# Altium schematic sheet PS_Board_p1.SchDoc
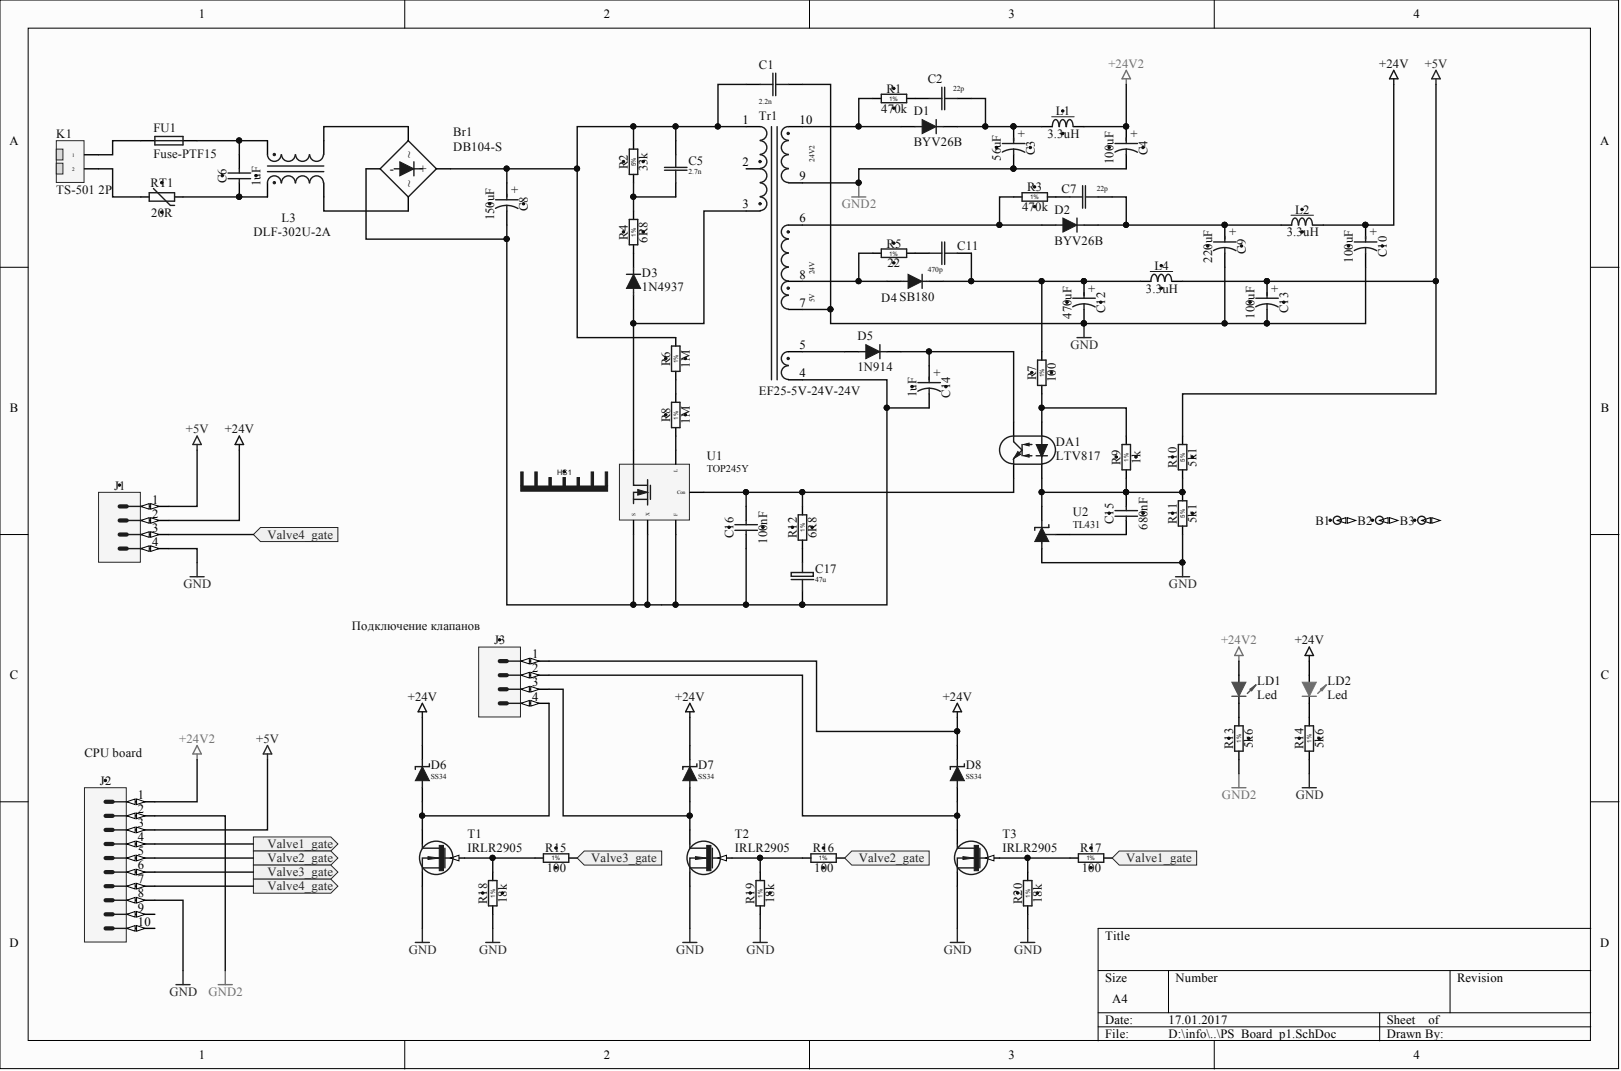
[diagram p0: PS_Board_p1.SchDoc]

## Parts
B1  Plated Hole  [MTG350_650]  (value not drawn)  (no BOM)
B2  Plated Hole  [MTG350_650]  (value not drawn)  (no BOM)
B3  Plated Hole  [MTG350_650]  (value not drawn)  (no BOM)
Br1  DB104-S  [DB-S]
C1  2.2n  [C Y2 7.5b]
C2  22p  [Cap-Disk-D5.5-R5.08]
C3  56uF  [CAPPR500-1050X1150]
C4  100uF  [CAPPR350-850X800]
C5  2.7n  [C Y2 7.5b]
C6  1uF  [CAPR2750-1300X3150X2400]
C7  22p  [Cap-Disk-D5.5-R5.08]
C8  150uF  [CAPPR1000-2300X4200]
C9  220uF  [CAPPR350-850X1300]
C10  100uF  [CAPPR350-850X800]
C11  470p  [Cap-Disk-D5.5-R5.08]
C12  470uF  [CAPPR500-1050X2200]
C13  100uF  [CAPPR350-850X800]
C14  1uF  [CAPPRB254-450X710]
C15  680nF  [CAPC2012X135N]
C16  100nF  [CAPC2012X135N]
C17  47u  [El-d5-h11-F2.54]
D1  BYV26B  [SOD-64-300]
D2  BYV26B  [SOD-64-300]
D3  1N4937  [DO-41-300]
D4  SB180  [DO-41-300]
D5  1N914  [DO-35-300]
D6  SS34  [DO-214AB (SMC)]
D7  SS34  [DO-214AB (SMC)]
D8  SS34  [DO-214AB (SMC)]
DA1  LTV817  [DIL4-300a]
FU1  Fuse-PTF15  [FUSE-MCH]
HS1  Heat Sink No Pad  [HSINK_FISCHER_FK 242 SA 220 O_V]  (value not drawn)
J1  KK®  [MOLEX_22-27-2041]  (value not drawn)
J2  C-Grid®  [MOLEX_70246-1004]  (value not drawn)
J3  KK®  [MOLEX_22-27-2041]  (value not drawn)
K1  TS-501 2P  [TS-501 2P-2.5]
L1  3.3uH  [IND_WURTH_WE-TI]
L2  3.3uH  [IND_WURTH_WE-TI]
L3  DLF-302U-2A  [DLF-302U-2A]
L4  3.3uH  [IND_WURTH_WE-TI]
LD1  Led  [Led 0805-Red]
LD2  Led  [Led 0805-Green]
R1  470k  [RES1016-630X250]
R2  33k  [RES2286-1670X520]
R3  470k  [RES1016-630X250]
R4  6R8  [RES1016-630X250]
R5  22  [RES1016-630X250]
R6  1M  [RES1016-630X250]
R7  100  [RES1016-630X250]
R8  1M  [RES1016-630X250]
R9  1k  [RES1016-630X250]
R10  5k1  [RES_ARCOL_RCC025]
R11  5k1  [RES_ARCOL_RCC025]
R12  6R8  [RES1016-630X250]
R13  5k6  [RESC2012X60N]
R14  5k6  [RESC2012X60N]
R15  100  [RESC2012X60N]
R16  100  [RESC2012X60N]
R17  100  [RESC2012X60N]
R18  18k  [RESC2012X60N]
R19  18k  [RESC2012X60N]
R20  18k  [RESC2012X60N]
RT1  20R  [THERM_EPCOS_B57153S0]
T1  IRLR2905  [TO252AA]
T2  IRLR2905  [TO252AA]
T3  IRLR2905  [TO252AA]
Tr1  EF25-5V-24V-24V  [SP-EF25]
U1  TOP245Y  [TO220-7C]
U2  TL431  [TO92C]

## Ports
VALVE1_GATE, VALVE2_GATE, VALVE3_GATE, VALVE4_GATE

## Nets
+5V: C13.1 J1.1 J2.3 L4.2 R10.2
+24V: C10.1 D6.2 D7.2 D8.2 J1.2 J3.1 L2.2 LD2.1
+24V2: C4.1 J2.1 L1.2 LD1.1
GND: C1.2 C9.2 C10.2 C12.2 C13.2 J1.4 J2.8 R11.1 R14.1 R18.1 R19.1 R20.1 T1.3 T2.3 T3.3 Tr1.7 U2.2
GND2: C3.2 C4.2 J2.2 R13.1 Tr1.9
NetBr1_1: Br1.1(+) C1.1 C5.2 C8.1 R2.2 R6.2 Tr1.1
NetBr1_2: Br1.2(-) C8.2 C14.2 C16.1 C17.2 Tr1.4 U1.3 U1.4(S) U1.5(F)
NetBr1_3: Br1.3(~) L3.3
NetBr1_4: Br1.4(~) L3.4
NetC2_1: C2.1 R1.2
NetC2_2: C2.2 C3.1 D1.2 L1.1
NetC5_1: C5.1 R2.1 R4.2
NetC6_1: C6.1 L3.2 RT1.2
NetC6_2: C6.2 FU1.1 L3.1
NetC7_1: C7.1 R3.2
NetC7_2: C7.2 C9.1 D2.2 L2.1
NetC11_1: C11.1 R5.2
NetC11_2: C11.2 C12.1 D4.2 L4.1 R7.2
NetC14_1: C14.1 D5.2 DA1.4
NetC15_1: C15.1 U2.3
NetC15_2: C15.2 DA1.2 R9.1 R10.1 R11.2 U2.1
NetC16_2: C16.2 DA1.3 R12.2 U1.1(Con)
NetC17_1: C17.1 R12.1
NetD1_1: D1.1 R1.1 Tr1.10
NetD2_1: D2.1 R3.1 Tr1.6
NetD3_1: D3.1 Tr1.3 U1.7
NetD3_2: D3.2 R4.1
NetD4_1: D4.1 R5.1 Tr1.8
NetD5_1: D5.1 Tr1.5
NetD6_1: D6.1 J3.4 T1.2
NetD7_1: D7.1 J3.3 T2.2
NetD8_1: D8.1 J3.2 T3.2
NetDA1_1: DA1.1 R7.1 R9.2
NetFU1_2: FU1.2 K1.1
NetJ1_3: J1.3 J2.7 ; port VALVE4_GATE
NetJ2_4: J2.4 R17.2 ; port VALVE1_GATE
NetJ2_5: J2.5 R16.2 ; port VALVE2_GATE
NetJ2_6: J2.6 R15.2 ; port VALVE3_GATE
NetK1_2: K1.2 RT1.1
NetLD1_2: LD1.2 R13.2
NetLD2_2: LD2.2 R14.2
NetR6_1: R6.1 R8.2
NetR8_1: R8.1 U1.2(L)
NetR15_1: R15.1 R18.2 T1.1
NetR16_1: R16.1 R19.2 T2.1
NetR17_1: R17.1 R20.2 T3.1

Not connected: B1 1; B2 1; B3 1; J2 9 10; Tr1 2

## Library data of the parts
B1-B3 = PLATED_HOLE3.5_PAD6.5: Single Terminal Socket; Part Description: Plated Through Hole: Hole Dia.=3.5mm Pad Dia.=6.5mm; Database Table Name: Pads; Mounted: No; ComponentHeight: 0mm; Component Type: Standard (No BOM); CreateDate: 20.11.2008; Family: Plated Hole; LatestRevisionDate: 23.11.2011; Library Name: Eletro-mechanical.DbLib; PackageDescription: Plated Through Hole: Hole Dia.=3.5mm Pad Dia.=6.5mm; Part Number: PLATED_HOLE3.5_PAD6.5; Pin Count: 1; SMD: No; Socket: No; Status: None; Table: Pads; Author: CERN DEM JLC
Br1 = DB104-S: SMD 1A 400V; Component Kind: Standard; Library Name: Diodes-Bridge.IntLib; Price: 0.23; Signal Integrity: D
C1 C5 = Y2 1n 250V AC: Y2 250V AC Y5P; Tip: Ceramic  Disc Y2 1nF 250VAC Y5P; Component Kind: Standard; Library Name: Cap.IntLib; Price: 0.1; Signal Integrity: C; Simulation: C
  C1: Value: 2.2n
  C5: Value: 2.7n
C2 C7 = Cer Disc HV 220p 1kV: 22p 1kV Y5P; Tip: Suntan TS16 220pF 1kV Y5P; Component Kind: Standard; Library Name: Cap.IntLib; Price: 0.072; Signal Integrity: C; Simulation: C; Value: 22p
C3 = CAER_56UF_25V_20%_500-1050X1150_LESR0R025: Polarised capacitor; Manufacturer: SANYO OS-CON; Manufacturer Part Number: 25SP56M; Part Description: Low ESR 0R025 Aluminum Solid Capacitor With Organic Semiconductive Electrolyte; Database Table Name: Capacitors THD; Tolerance: ±20%; Voltage: 25V; Case: LS5 D10.5 x H11.5; ComponentHeight: 11.5mm; Component Kind: Standard; Component Type: Standard; CreateDate: 29.01.2008; HelpURL: \\cern.ch\dfs\Applications\Altium\Datasheets\CAER-LESR_SANYO_SP.pdf; LatestRevisionDate: 16.03.2009; Library Name: Capacitors.DbLib; Mounted: Yes; Part Number: CAER_56UF_25V_20%_500-1050X1150_LESR0R025; Pin Count: 2; SMD: No; Socket: No; Status: Preferred; Table: Capacitors THD; Value: 56uF; Author: CERN DEM JLC
C4 C10 C13 = CAER_100UF_25V_20%_RUBYCON_25ML100M8X7: Polarised capacitor; Part Description: Aluminum Electrolytic Capacitor, (ML Series); Database Table Name: Capacitors THD; Tolerance: ±20%; Voltage: 25V; Case: LS3.5 D8.5 x H8; ComponentHeight: 8mm; Component Kind: Standard; Component Type: Standard; CreateDate: 17.11.2008; HelpURL: \\cern.ch\dfs\Applications\Altium\Datasheets\CAER_RUBYCON_25ML100M8X7.pdf; LatestRevisionDate: 08.05.2013; Library Name: Capacitors.DbLib; Manufacturer: RUBYCON; Manufacturer Part Number: 25ML100M8X7; Mounted: Yes; Part Number: CAER_100UF_25V_20%_RUBYCON_25ML100M8X7; Pin Count: 2; SMD: No; Socket: No; Status: None; Table: Capacitors THD; Value: 100uF; Author: CERN DEM JMW
C6 = CPPR_1UF_275VAC_20%_2750-1300X3150X2400: Non-polarised capacitor; Manufacturer: WIMA; Manufacturer Part Number: MKX21W41006D00MSSD; Part Description: Metallized Polypropylene RFI Capacitor Class X2 (MKP-X2); Database Table Name: Capacitors THD; Tolerance: ±20%; Voltage: 275VAC; Case: LS27.5 W13 x L31.5 x H24; ComponentHeight: 24mm; Component Kind: Standard; Component Type: Standard; CreateDate: 23.06.2008; HelpURL: \\cern.ch\dfs\Applications\Altium\Datasheets\CPPR_WIMA_MKP-X2.pdf; LatestRevisionDate: 30.01.2012; Library Name: Capacitors.DbLib; Mounted: Yes; Part Number: CPPR_1UF_275VAC_20%_2750-1300X3150X2400; Pin Count: 2; SMD: No; Socket: No; Status: None; Table: Capacitors THD; Value: 1uF; Author: CERN DEM JLC
C8 = CAER_150UF_400V_20%_EPCOS_B43501A9157M000: Polarised capacitor; Manufacturer: EPCOS; Part Description: Aluminum Electrolytic Capacitor  (B43501 Series); Database Table Name: Capacitors THD; Tolerance: ±20%; Voltage: 400V; Case: LS10 D22 x H40; ComponentHeight: 42mm; Component Kind: Standard; Component Type: Standard; CreateDate: 15.09.2011; HelpURL: \\cern.ch\dfs\Applications\Altium\Datasheets\CAER_EPCOS_B43501.pdf; LatestRevisionDate: 15.09.2011; Library Name: Capacitors.DbLib; Manufacturer Part Number: B43501A9157M000; Mounted: Yes; Part Number: CAER_150UF_400V_20%_EPCOS_B43501A9157M000; Pin Count: 2; SMD: No; Socket: No; Status: None; Table: Capacitors THD; Value: 150uF; Author: CERN DEM JLC
C9 = CAER_220UF_25V_20%_RUBYCON_25YXF220M8X11.5: Polarised capacitor; Part Description: Radial Miniature, Aluminum Electrolytic Capacitor, (YXF Series); Database Table Name: Capacitors THD; Tolerance: ±20%; Voltage: 25V; Case: LS3.5 D8.5 x H13; ComponentHeight: 13mm; Component Kind: Standard; Component Type: Standard; CreateDate: 20.08.2009; HelpURL: \\cern.ch\dfs\Applications\Altium\Datasheets\CAER_RUBYCON_YXF.pdf; LatestRevisionDate: 17.09.2009; Library Name: Capacitors.DbLib; Manufacturer: RUBYCON; Manufacturer Part Number: 25YXF220M8X11.5; Mounted: Yes; Part Number: CAER_220UF_25V_20%_RUBYCON_25YXF220M8X11.5; Pin Count: 2; SMD: No; Socket: No; Status: None; Table: Capacitors THD; Value: 220uF; Author: CERN DEM JLC
C11 = Cer Disc HV 220p 1kV: 470p 1kV Y5P; Tip: Suntan TS16 220pF 1kV Y5P; Component Kind: Standard; Library Name: Cap.IntLib; Price: 0.072; Signal Integrity: C; Simulation: C; Value: 470p
C12 = CAER_470UF_25V_20%_500-1050X2200_LESR0R062: Polarised capacitor; Manufacturer: VISHAY BCCOMPONENTS; Manufacturer Part Number: MAL213666471E3 Series 136RVI; Part Description: Low ESR 0R062 Aluminum Electrolytic Capacitor; Database Table Name: Capacitors THD; Tolerance: ±20%; Voltage: 25V; Case: LS5 D10.5 x H22; ComponentHeight: 22mm; Component Kind: Standard; Component Type: Standard; CreateDate: 03.07.2008; HelpURL: \\cern.ch\dfs\Applications\Altium\Datasheets\CAER-LESR_VISHAY_136RVI.pdf; LatestRevisionDate: 16.03.2009; Library Name: Capacitors.DbLib; Mounted: Yes; Part Number: CAER_470UF_25V_20%_500-1050X2200_LESR0R062; Pin Count: 2; SMD: No; Socket: No; Status: Preferred; Table: Capacitors THD; Value: 470uF; Author: CERN DEM JLC
C14 = CTEB_1UF_35V_10%_254-450X710: Polarised capacitor; Manufacturer: KEMET; Manufacturer Part Number: T350A105K035AT; Part Description: Solid Tantalum Resin Dipped Capacitor; Database Table Name: Capacitors THD; Tolerance: ±10%; Voltage: 35V; Case: LS2.54 D4.5 x H7.1; ComponentHeight: 7.1mm; Component Kind: Standard; Component Type: Standard; CreateDate: 16.12.2009; HelpURL: \\cern.ch\dfs\Applications\Altium\Datasheets\CTEB_KEMET_T350.pdf; LatestRevisionDate: 16.12.2009; Library Name: Capacitors.DbLib; Mounted: Yes; Part Number: CTEB_1UF_35V_10%_254-450X710; Pin Count: 2; SMD: No; Socket: No; Status: None; Table: Capacitors THD; Value: 1uF; Author: CERN DEM JLC
C15 = CC0805_680NF_16V_10%_X7R: Non-polarised capacitor; Manufacturer: GENERIC; Manufacturer1 Part Number: C0805C684K5RAC; Part Description: SMD Multilayer Chip Ceramic Capacitor; Database Table Name: Capacitors SMD; TC: X7R; Tolerance: ±10%; Voltage: 16V; Case: 0805; Component Kind: Standard; Component Type: Standard; CreateDate: 03.12.2007; HelpURL: \\cern.ch\dfs\Applications\Altium\Datasheets\CC0805_X7R_KEMET.pdf; LatestRevisionDate: 03.12.2007; Library Name: Capacitors.DbLib; Manufacturer1 ComponentHeight: 1.4mm; Manufacturer1 Example: KEMET; Manufacturer Part Number: CC0805_680NF_16V_10%_X7R; Mounted: Yes; Part Number: CC0805_680NF_16V_10%_X7R; Pin Count: 2; SMD: Yes; Socket: No; Status: Preferred; Table: Capacitors SMD; Value: 680nF; Author: CERN DEM JLC
C16 = CC0805_100NF_50V_10%_X7R: Non-polarised capacitor; Manufacturer: GENERIC; Manufacturer1 Part Number: B37941K5104K62; Part Description: SMD Multilayer Chip Ceramic Capacitor; Database Table Name: Capacitors SMD; TC: X7R; Tolerance: ±10%; Voltage: 50V; Case: 0805; Component Kind: Standard; Component Type: Standard; CreateDate: 03.12.2007; HelpURL: \\cern.ch\dfs\Applications\Altium\Datasheets\CC0805_X7R_EPCOS.pdf; LatestRevisionDate: 03.12.2007; Library Name: Capacitors.DbLib; Manufacturer1 ComponentHeight: 1.35mm; Manufacturer1 Example: EPCOS; Manufacturer Part Number: CC0805_100NF_50V_10%_X7R; Mounted: Yes; Part Number: CC0805_100NF_50V_10%_X7R; Pin Count: 2; SMD: Yes; Socket: No; Status: Preferred; Table: Capacitors SMD; Value: 100nF; Author: CERN DEM JLC
C17 = 47u-25V-105: 25V-105; Component Kind: Standard; Library Name: Cap-El.IntLib; Price: 0.06; Signal Integrity: C; Simulation: C; Value: 47u
D1 D2 = BYV27-100: 100V 2A 25nS; Component Kind: Standard; Library Name: Diodes-Power.IntLib; Price: 0.58; Signal Integrity: D; Simulation: BYV27-100
D3 = 1N4007: 1000V 1A; Component Kind: Standard; Library Name: Diodes-Power.IntLib; Price: 0.05; Signal Integrity: D; Simulation: D1N4007
D4 = 1N4007: Component Kind: Standard; Library Name: Diodes-Power.IntLib; Price: 0.05; Signal Integrity: D; Simulation: D1N4007
D5 = 1N4148: DO-35 75V 0.2A 1uS 4A 4nS; Component Kind: Standard; Library Name: Diodes.IntLib; Price: 0.02; Signal Integrity: D; Simulation: 1N4148
D6-D8 = SS34: SMD SMC  40V 3A; Component Kind: Standard; Library Name: Diodes-Power.IntLib; Price: 0.14; Signal Integrity: D
FU1 = Fuse-PTF15: 5mm 6.3A; Component Kind: Standard; Library Name: Devise.IntLib; Price: 1
HS1 = HSINK_FISCHER_FK 242 SA 220 O_v: Heat Sink Without Pad; Manufacturer: FISCHER ELEKTRONIK; Part Description: TO-220 Heat Sink  Rth: 26°C/W - Vertical Mount; Database Table Name: Heat-Sinks; ComponentHeight: 15.24mm; Component Kind: Standard; Component Type: Standard; CreateDate: 02.11.2009; HelpURL: \\cern.ch\dfs\Applications\Altium\Datasheets\HSINK_FISCHER_FK 242 SA 220 O.pdf; LatestRevisionDate: 10.10.2011; Library Name: Eletro-mechanical.DbLib; Manufacturer Part Number: FK 242 SA 220 O; Mounted: Yes; PackageDescription: TO-220 Heat Sink  Rth: 26°C/W - Vertical Mount; Part Number: HSINK_FISCHER_FK 242 SA 220 O_v; Pin Count: 0; SMD: No; Socket: No; Status: None; Table: Heat-Sinks; Author: CERN DEM JLC
J1 J3 = MOLEX_22-27-2041: Connector 4 Male; Part Description: 2.54mm (.100") Pitch KK® Wire-to-Board Header, Vertical, with Friction Lock, 4 Circuits, Tin (Sn) Plating; Database Table Name: MOLEX; ComponentHeight: 11.7mm; Component Kind: Standard; Component Type: Standard; CreateDate: 04.12.2008; Family: KK®; HelpURL: \\cern.ch\dfs\Applications\Altium\Datasheets\MOLEX_22-27-2041.pdf; LatestRevisionDate: 04.12.2008; Library Name: Connectors.DbLib; Manufacturer: MOLEX; Manufacturer Part Number: 22-27-2041; Mounted: Yes; Part Number: MOLEX_22-27-2041; Pin Count: 4; SMD: No; Socket: No; Status: None; Table: MOLEX; Author: CERN DEM JLC
J2 = MOLEX_70246-1004: Connector 10 Male; Part Description: 2.54mm Pitch C-Grid® Header, Low Profile, Dual Row, Vertical, Shrouded Header; Database Table Name: MOLEX; ComponentHeight: 9.27mm; Component Kind: Standard; Component Type: Standard; CreateDate: 14.08.2013; Family: C-Grid®; HelpURL: \\cern.ch\dfs\Applications\Altium\Datasheets\MOLEX_70246-1004.pdf; LatestRevisionDate: 14.08.2013; Library Name: Connectors.DbLib; Manufacturer: MOLEX; Manufacturer Part Number: 70246-1004; Mounted: Yes; Part Number: MOLEX_70246-1004; Pin Count: 10; SMD: No; Socket: No; Status: None; Table: MOLEX; Author: CERN DEM JLC
K1 = TS-501 2P: 10A/300V AC 5mm; Component Kind: Standard; Library Name: Connektor.IntLib; Price: 0.27
L1 L2 L4 = IND_3.3UH_20%_WURTH_WE-TI: Inductor With Magnetic core; Manufacturer: WURTH ELEKTRONIK; Manufacturer Part Number: 744772033; Part Description: Radial Leaded Filter Choke Serie WE-TI; Database Table Name: Inductors THD; Power: 5A; Resistance: 0.013R; Tolerance: ±20%; Case: LS5.08 H10 x D8.3; ComponentHeight: 10mm; Component Kind: Standard; Component Type: Standard; CreateDate: 11.11.2008; HelpURL: \\cern.ch\dfs\Applications\Altium\Datasheets\IND_WURTH_WE-TI_744772033.pdf; LatestRevisionDate: 09.04.2014; Library Name: Inductors & Transformers.DbLib; Mounted: Yes; Part Number: IND_3.3UH_20%_WURTH_WE-TI; Pin Count: 2; SMD: No; Socket: No; Table: Inductors THD; Value: 3.3uH; Author: CERN DEM JLC
LD1 = Led 0805 KP-2012EC: Red  627nm 12mcd; Component Kind: Standard; Library Name: Ind LED-LCD.IntLib; Price: 0.09
LD2 = Led 0805 KP-2012SGC: Green 565nm 15mcd; Component Kind: Standard; Library Name: Ind LED-LCD.IntLib; Price: 0.09
R1 R3 = RMF_470K_1%_0.6W_50PPM_1016-630X250: Resistor - 1%; Manufacturer: GENERIC; Manufacturer1 Part Number: MBB02070C4703FCT00; Part Description: Leaded Metal Film Resistor; Database Table Name: Resistors THD; Power: 0.6W; TC: ±50ppm/°C; Case: LS10.16 L6.3 x D2.5; ComponentHeight: 2.5mm; Component Kind: Standard; Component Type: Standard; CreateDate: 04.12.2007; HelpURL: \\cern.ch\dfs\Applications\Altium\Datasheets\RMF_VISHAY_MBB0207.pdf; LatestRevisionDate: 07.04.2008; Library Name: Resistors.DbLib; Manufacturer1 ComponentHeight: 2.5mm; Manufacturer1 Example: VISHAY BEYSCHLAG; Manufacturer Part Number: RMF_470K_1%_0.6W_50PPM_1016-630X250; Mounted: Yes; Part Number: RMF_470K_1%_0.6W_50PPM_1016-630X250; Pin Count: 2; SMD: No; Socket: No; Status: Preferred; Table: Resistors THD; Tolerance: ±1%; Value: 470k; Author: CERN DEM JLC
R2 = RMF_33K_5%_3W_250PPM_2286-1670X520: Resistor - 5%; Manufacturer: GENERIC; Manufacturer1 Part Number: PR03000203302JAC00; Part Description: Leaded Power Metal Film Resistor; Database Table Name: Resistors THD; Power: 3W; TC: ±250ppm/°C; Case: LS22.86 L16.7 x D5.2; ComponentHeight: 5.2mm; Component Kind: Standard; Component Type: Standard; CreateDate: 23.06.2008; HelpURL: \\cern.ch\dfs\Applications\Altium\Datasheets\RMF_VISHAY_PR03.pdf; LatestRevisionDate: 01.10.2012; Library Name: Resistors.DbLib; Manufacturer1 ComponentHeight: 5.2mm; Manufacturer1 Example: VISHAY BCCOMPONENT; Manufacturer Part Number: RMF_33K_5%_3W_250PPM_2286-1670X520; Mounted: Yes; Part Number: RMF_33K_5%_3W_250PPM_2286-1670X520; Pin Count: 2; SMD: No; Socket: No; Status: Preferred; Table: Resistors THD; Tolerance: ±5%; Value: 33k; Author: CERN DEM JLC
R4 R12 = RMF_6R8_1%_0.6W_50PPM_1016-630X250: Resistor - 1%; Manufacturer: GENERIC; Manufacturer1 Part Number: MBB02070C6808FCT00; Part Description: Leaded Metal Film Resistor; Database Table Name: Resistors THD; Power: 0.6W; TC: ±50ppm/°C; Case: LS10.16 L6.3 x D2.5; ComponentHeight: 2.5mm; Component Kind: Standard; Component Type: Standard; CreateDate: 04.12.2007; HelpURL: \\cern.ch\dfs\Applications\Altium\Datasheets\RMF_VISHAY_MBB0207.pdf; LatestRevisionDate: 07.04.2008; Library Name: Resistors.DbLib; Manufacturer1 ComponentHeight: 2.5mm; Manufacturer1 Example: VISHAY BEYSCHLAG; Manufacturer Part Number: RMF_6R8_1%_0.6W_50PPM_1016-630X250; Mounted: Yes; Part Number: RMF_6R8_1%_0.6W_50PPM_1016-630X250; Pin Count: 2; SMD: No; Socket: No; Status: Not recommended; Table: Resistors THD; Tolerance: ±1%; Value: 6R8; Author: CERN DEM JLC
R5 = RMF_22R_1%_0.6W_50PPM_1016-630X250: Resistor - 1%; Manufacturer: GENERIC; Manufacturer1 Part Number: MBB02070C2209FCT00; Part Description: Leaded Metal Film Resistor; Database Table Name: Resistors THD; Power: 0.6W; TC: ±50ppm/°C; Case: LS10.16 L6.3 x D2.5; ComponentHeight: 2.5mm; Component Kind: Standard; Component Type: Standard; CreateDate: 04.12.2007; HelpURL: \\cern.ch\dfs\Applications\Altium\Datasheets\RMF_VISHAY_MBB0207.pdf; LatestRevisionDate: 07.04.2008; Library Name: Resistors.DbLib; Manufacturer1 ComponentHeight: 2.5mm; Manufacturer1 Example: VISHAY BEYSCHLAG; Manufacturer Part Number: RMF_22R_1%_0.6W_50PPM_1016-630X250; Mounted: Yes; Part Number: RMF_22R_1%_0.6W_50PPM_1016-630X250; Pin Count: 2; SMD: No; Socket: No; Status: Preferred; Table: Resistors THD; Tolerance: ±1%; Value: 22; Author: CERN DEM JLC
R6 R8 = RMF_1M_1%_0.6W_50PPM_1016-630X250: Resistor - 1%; Manufacturer: GENERIC; Manufacturer1 Part Number: MBB02070C1004FCT00; Part Description: Leaded Metal Film Resistor; Database Table Name: Resistors THD; Power: 0.6W; TC: ±50ppm/°C; Case: LS10.16 L6.3 x D2.5; ComponentHeight: 2.5mm; Component Kind: Standard; Component Type: Standard; CreateDate: 04.12.2007; HelpURL: \\cern.ch\dfs\Applications\Altium\Datasheets\RMF_VISHAY_MBB0207.pdf; LatestRevisionDate: 07.04.2008; Library Name: Resistors.DbLib; Manufacturer1 ComponentHeight: 2.5mm; Manufacturer1 Example: VISHAY BEYSCHLAG; Manufacturer Part Number: RMF_1M_1%_0.6W_50PPM_1016-630X250; Mounted: Yes; Part Number: RMF_1M_1%_0.6W_50PPM_1016-630X250; Pin Count: 2; SMD: No; Socket: No; Status: Preferred; Table: Resistors THD; Tolerance: ±1%; Value: 1M; Author: CERN DEM JLC
R7 = RMF_100R_1%_0.6W_50PPM_1016-630X250: Resistor - 1%; Manufacturer: GENERIC; Manufacturer1 Part Number: MBB02070C1100FCT00; Part Description: Leaded Metal Film Resistor; Database Table Name: Resistors THD; Power: 0.6W; TC: ±50ppm/°C; Case: LS10.16 L6.3 x D2.5; ComponentHeight: 2.5mm; Component Kind: Standard; Component Type: Standard; CreateDate: 04.12.2007; HelpURL: \\cern.ch\dfs\Applications\Altium\Datasheets\RMF_VISHAY_MBB0207.pdf; LatestRevisionDate: 07.04.2008; Library Name: Resistors.DbLib; Manufacturer1 ComponentHeight: 2.5mm; Manufacturer1 Example: VISHAY BEYSCHLAG; Manufacturer Part Number: RMF_100R_1%_0.6W_50PPM_1016-630X250; Mounted: Yes; Part Number: RMF_100R_1%_0.6W_50PPM_1016-630X250; Pin Count: 2; SMD: No; Socket: No; Status: Preferred; Table: Resistors THD; Tolerance: ±1%; Value: 100; Author: CERN DEM JLC
R9 = RMF_1K_1%_0.6W_50PPM_1016-630X250: Resistor - 1%; Manufacturer: GENERIC; Manufacturer1 Part Number: MBB02070C1001FCT00; Part Description: Leaded Metal Film Resistor; Database Table Name: Resistors THD; Power: 0.6W; TC: ±50ppm/°C; Case: LS10.16 L6.3 x D2.5; ComponentHeight: 2.5mm; Component Kind: Standard; Component Type: Standard; CreateDate: 04.12.2007; HelpURL: \\cern.ch\dfs\Applications\Altium\Datasheets\RMF_VISHAY_MBB0207.pdf; LatestRevisionDate: 07.04.2008; Library Name: Resistors.DbLib; Manufacturer1 ComponentHeight: 2.5mm; Manufacturer1 Example: VISHAY BEYSCHLAG; Manufacturer Part Number: RMF_1K_1%_0.6W_50PPM_1016-630X250; Mounted: Yes; Part Number: RMF_1K_1%_0.6W_50PPM_1016-630X250; Pin Count: 2; SMD: No; Socket: No; Status: Preferred; Table: Resistors THD; Tolerance: ±1%; Value: 1k; Author: CERN DEM JLC
R10 R11 = RCF_5K1_5%_0.25W_+10-6PPM_ARCOL_RCC025: Resistor - 5%; Manufacturer: ARCOL; Manufacturer Part Number: RCC025 5k1 J; Part Description: Solid Carbon Resistor RCC Series; Database Table Name: Resistors THD; Power: 0.25W; TC: +10-6ppm/°C; Case: LS10.16 L6.3 x D2.4; ComponentHeight: 2.5mm; Component Kind: Standard; Component Type: Standard; CreateDate: 30.10.2013; HelpURL: \\cern.ch\dfs\Applications\Altium\Datasheets\RCF_ARCOL_RCC025.pdf; LatestRevisionDate: 30.10.2013; Library Name: Resistors.DbLib; Mounted: Yes; Part Number: RCF_5K1_5%_0.25W_+10-6PPM_ARCOL_RCC025; Pin Count: 2; SMD: No; Socket: No; Status: None; Table: Resistors THD; Tolerance: ±5%; Value: 5k1; Author: CERN DEM JLC
R13 R14 = R0805_5K6_1%_0.125W_100PPM: Resistor - 1%; Manufacturer: GENERIC; Manufacturer1 Part Number: NRC10F5601TRF; Part Description: General Purpose Thick Film Chip Resistor; Database Table Name: Resistors SMD; Power: 0.125W; TC: ±100ppm/°C; Case: 0805; Component Kind: Standard; Component Type: Standard; CreateDate: 03.12.2007; HelpURL: \\cern.ch\dfs\Applications\Altium\Datasheets\R0805_NIC_NRC.pdf; LatestRevisionDate: 13.03.2008; Library Name: Resistors.DbLib; Manufacturer1 ComponentHeight: 0.6mm; Manufacturer1 Example: NIC COMPONENT; Manufacturer Part Number: R0805_5K6_1%_0.125W_100PPM; Mounted: Yes; Part Number: R0805_5K6_1%_0.125W_100PPM; Pin Count: 2; SMD: Yes; Socket: No; Status: Preferred; Table: Resistors SMD; Tolerance: ±1%; Value: 5k6; Author: CERN DEM JLC
R15-R17 = R0805_100R_1%_0.125W_100PPM: Resistor - 1%; Manufacturer: GENERIC; Manufacturer1 Part Number: NRC10F4700TRF; Part Description: General Purpose Thick Film Chip Resistor; Database Table Name: Resistors SMD; Power: 0.125W; TC: ±100ppm/°C; Case: 0805; Component Kind: Standard; Component Type: Standard; CreateDate: 03.12.2007; HelpURL: \\cern.ch\dfs\Applications\Altium\Datasheets\R0805_NIC_NRC.pdf; LatestRevisionDate: 13.03.2008; Library Name: Resistors.DbLib; Manufacturer1 ComponentHeight: 0.6mm; Manufacturer1 Example: NIC COMPONENT; Manufacturer Part Number: R0805_100R_1%_0.125W_100PPM; Mounted: Yes; Part Number: R0805_100R_1%_0.125W_100PPM; Pin Count: 2; SMD: Yes; Socket: No; Status: Preferred; Table: Resistors SMD; Tolerance: ±1%; Value: 100; Author: CERN DEM JLC
R18-R20 = R0805_18K_1%_0.125W_100PPM: Resistor - 1%; Manufacturer: GENERIC; Manufacturer1 Part Number: NRC10F1802TRF; Part Description: General Purpose Thick Film Chip Resistor; Database Table Name: Resistors SMD; Power: 0.125W; TC: ±100ppm/°C; Case: 0805; Component Kind: Standard; Component Type: Standard; CreateDate: 03.12.2007; HelpURL: \\cern.ch\dfs\Applications\Altium\Datasheets\R0805_NIC_NRC.pdf; LatestRevisionDate: 13.03.2008; Library Name: Resistors.DbLib; Manufacturer1 ComponentHeight: 0.6mm; Manufacturer1 Example: NIC COMPONENT; Manufacturer Part Number: R0805_18K_1%_0.125W_100PPM; Mounted: Yes; Part Number: R0805_18K_1%_0.125W_100PPM; Pin Count: 2; SMD: Yes; Socket: No; Status: Preferred; Table: Resistors SMD; Tolerance: ±1%; Value: 18k; Author: CERN DEM JLC
RT1 = THERM_NTC_20R_EPCOS_B57153S0200M000: Thermistor NTC (Negative Temperature Coefficient); Manufacturer: EPCOS; Part Description: Radial Leaded NTC Thermistor For Inrush Current Limiting; Database Table Name: Thermistors And Varistors; Power: 1.6A; Tolerance: ±20%; Voltage: 265VAC; ComponentHeight: 13mm; Component Kind: Standard; Component Type: Standard; CreateDate: 23.04.2012; HelpURL: \\cern.ch\dfs\Applications\Altium\Datasheets\THERM_EPCOS_B57153S0.pdf; LatestRevisionDate: 23.04.2012; Library Name: Resistors.DbLib; Manufacturer Part Number: B57153S0200M000; Mounted: Yes; Part Number: THERM_NTC_20R_EPCOS_B57153S0200M000; Pin Count: 2; SMD: No; Socket: No; Status: None; Table: Thermistors And Varistors; Value: 20R; Author: CERN DEM JLC
T1-T3 = IRLR2905: 55V 27moM 42A; Component Kind: Standard; Library Name: MOS-Power.IntLib; price: 2
U1 = TOP244Y: 45W 1.35A 132kHz; Component Kind: Standard; Library Name: Power IC.IntLib; Price: 6
DA1 = LTV817: Component Kind: Standard; Price: 0.28; Simulation: PC817; Library Name: Opto Coupler.IntLib
L3 = DLF-302U-2A: Component Kind: Standard; Description: DLF-302U-2A; Library Name: PowerLib.SchLib
Tr1 = EF25-5V-24V-24V: Component Kind: Standard; Description: EF25-5V-24V-24V; Library Name: PowerLib.SchLib
U2 = TL431: Component Kind: Standard; Library Name: Power IC.IntLib; Price: 0.24; Simulation: TL431
